# Altium schematic sheet s1_sensor.SchDoc
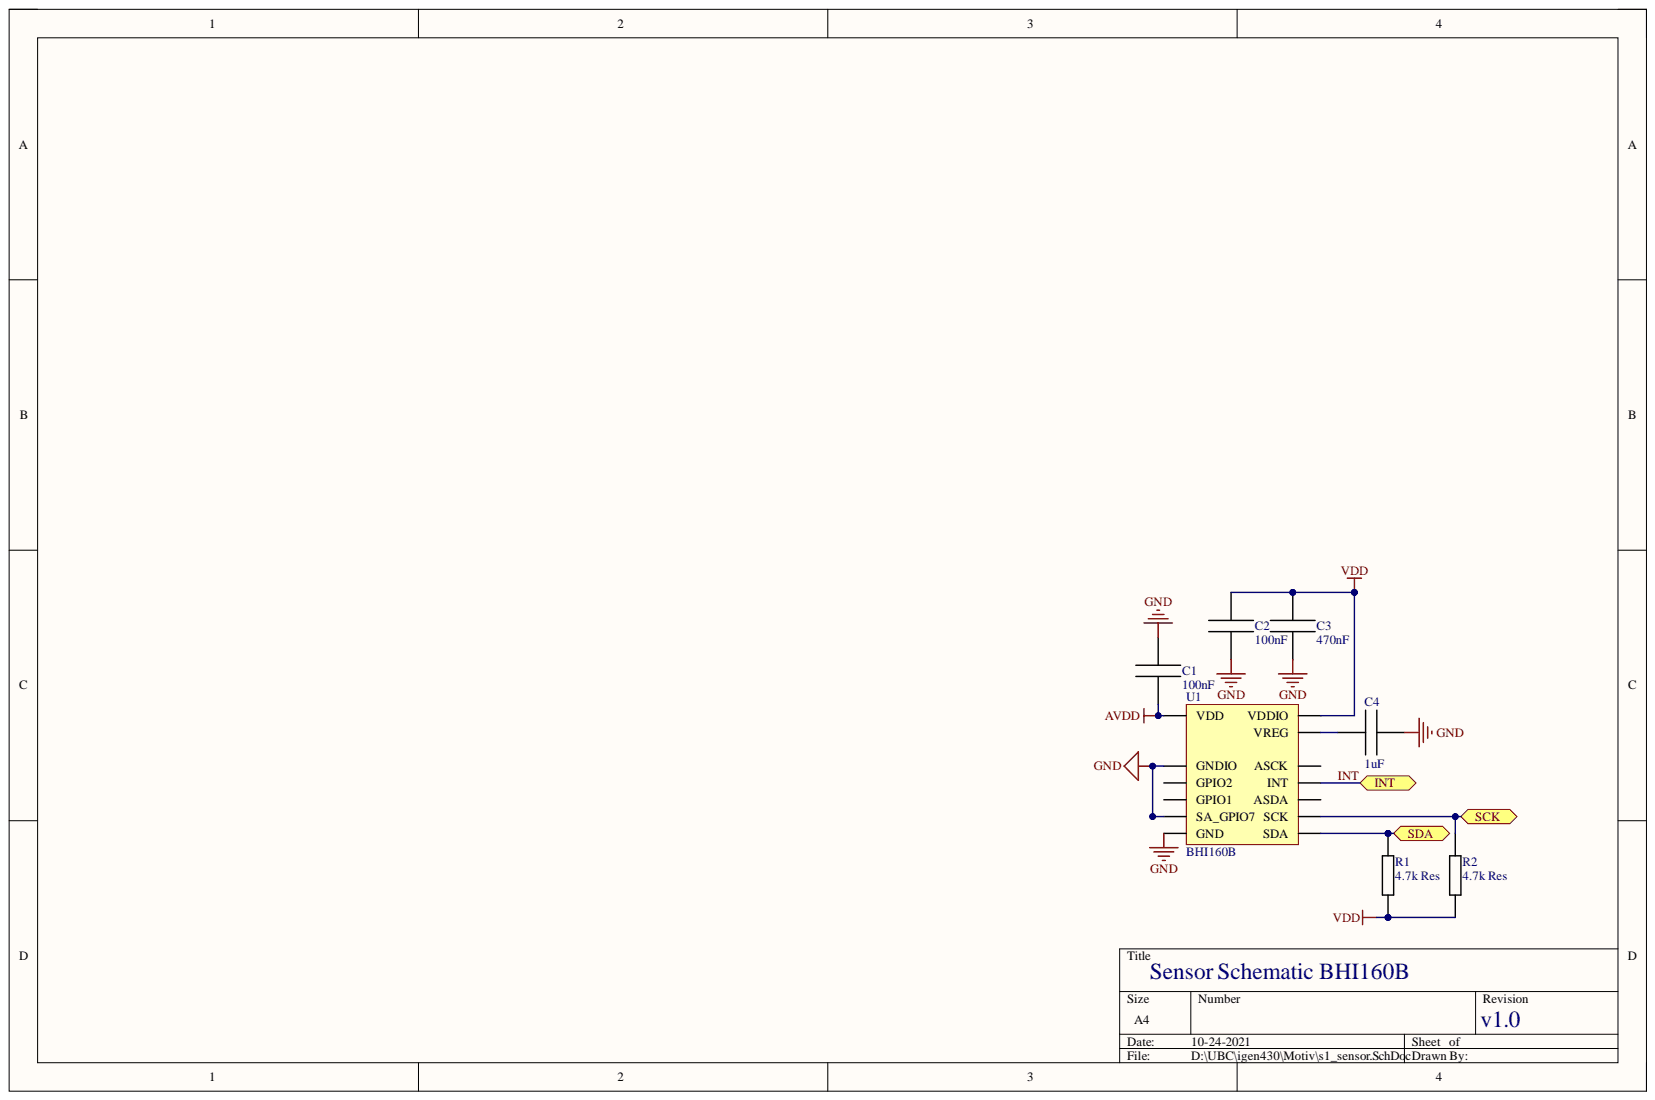
[diagram p0: s1_sensor.SchDoc]

## Parts
C1  100nF
C2  100nF
C3  470nF
C4  1uF
R1  4.7k Res
R2  4.7k Res
U1  BHI160B

## Ports
INT, SCK, SDA

## Nets
AVDD: C1.0 U1.13(VDD)
GND: C1.1 C2.0 C3.0 C4.1 U1.9(SA_GPIO7) U1.18(GND) U1.20(GNDIO)
VDD: C2.1 C3.1 R1.2 R2.1 U1.8(VDDIO)
INT: U1.12(INT) ; port INT
SCK: R2.2 U1.22(SCK) ; port SCK
SDA: R1.1 U1.24(SDA) ; port SDA
NetC4_0: C4.0 U1.10(VREG)

Not connected: U1 3(GPIO1) 7(ASCK) 11(GPIO2) 21(ASDA)

## Library data of the parts
C1 C2 = 100nF: Component Kind: Standard; Library Name: lib.SchLib
C3 = 470nF: Component Kind: Standard; Library Name: lib.SchLib
C4 = 1uF: Component Kind: Standard; Library Name: lib.SchLib
R1 R2 = 4.7k Res: Component Kind: Standard; Library Name: lib.SchLib
U1 = BHI160B: Component Kind: Standard; Library Name: lib.SchLib
